# Altium schematic sheet Sheet2.SchDoc(Sheet2)
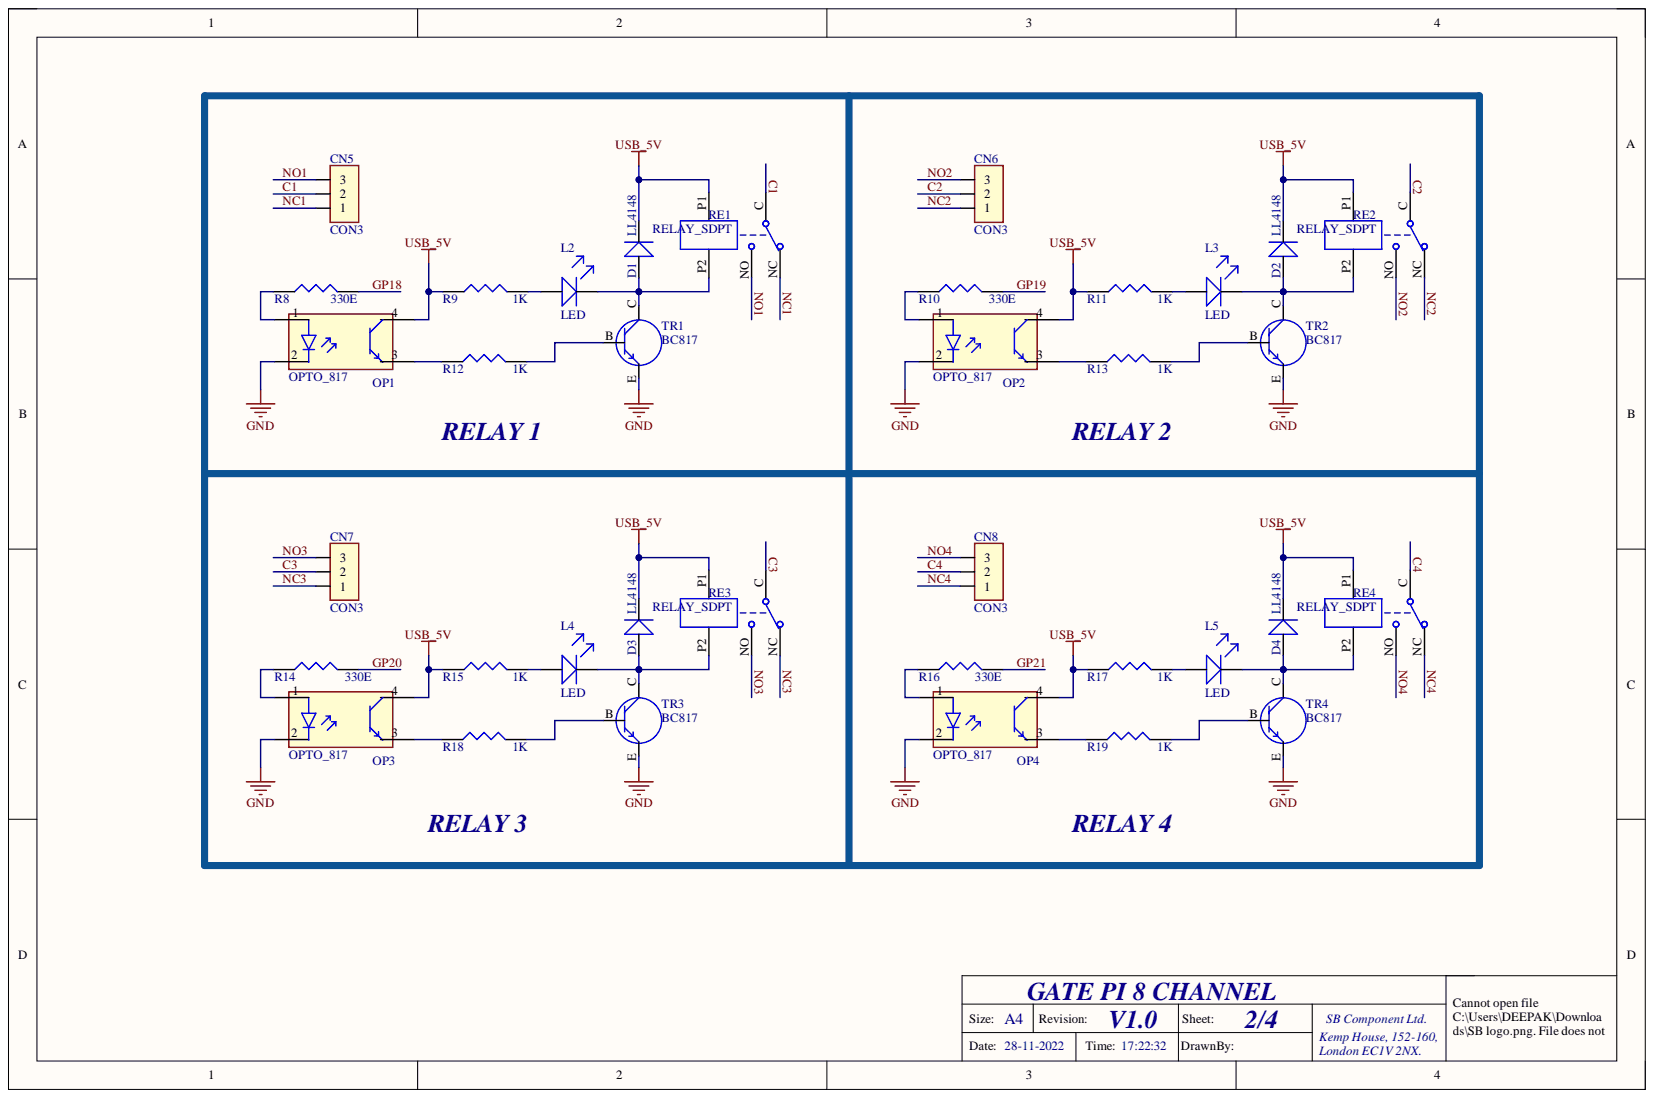
[diagram p0: Sheet2.SchDoc]

## Parts
CN5  CON3  [CON3_5.0_R2]
CN6  CON3  [CON3_5.0_R2]
CN7  CON3  [CON3_5.0_R2]
CN8  CON3  [CON3_5.0_R2]
D1  LL4148  [MINI_MELF_SOD80]
D2  LL4148  [MINI_MELF_SOD80]
D3  LL4148  [MINI_MELF_SOD80]
D4  LL4148  [MINI_MELF_SOD80]
L2  LED  [LED_0603_neg]
L3  LED  [LED_0603_neg]
L4  LED  [LED_0603_neg]
L5  LED  [LED_0603_neg]
OP1  OPTO_817  [OPTO_817_SMD]
OP2  OPTO_817  [OPTO_817_SMD]
OP3  OPTO_817  [OPTO_817_SMD]
OP4  OPTO_817  [OPTO_817_SMD]
R8  330E  [0603]
R9  1K  [0603]
R10  330E  [0603]
R11  1K  [0603]
R12  1K  [0603]
R13  1K  [0603]
R14  330E  [0603]
R15  1K  [0603]
R16  330E  [0603]
R17  1K  [0603]
R18  1K  [0603]
R19  1K  [0603]
RE1  RELAY_SDPT  [RELAY_SDPT]
RE2  RELAY_SDPT  [RELAY_SDPT]
RE3  RELAY_SDPT  [RELAY_SDPT]
RE4  RELAY_SDPT  [RELAY_SDPT]
TR1  BC817  [547_SOT23]
TR2  BC817  [547_SOT23]
TR3  BC817  [547_SOT23]
TR4  BC817  [547_SOT23]

## Nets
GND: OP1.2 OP2.2 OP3.2 OP4.2 TR1.E TR2.E TR3.E TR4.E
USB_5V: D1.K D2.K D3.K D4.K OP1.4 OP2.4 OP3.4 OP4.4 R9.1 R11.1 R15.1 R17.1 RE1.P1 RE2.P1 RE3.P1 RE4.P1
C1: CN5.2 RE1.C
C2: CN6.2 RE2.C
C3: CN7.2 RE3.C
C4: CN8.2 RE4.C
GP18: R8.2
GP19: R10.2
GP20: R14.2
GP21: R16.2
NC1: CN5.1 RE1.NC
NC2: CN6.1 RE2.NC
NC3: CN7.1 RE3.NC
NC4: CN8.1 RE4.NC
NO1: CN5.3 RE1.NO
NO2: CN6.3 RE2.NO
NO3: CN7.3 RE3.NO
NO4: CN8.3 RE4.NO
NetD1_A: D1.A L2.K RE1.P2 TR1.C
NetD2_A: D2.A L3.K RE2.P2 TR2.C
NetD3_A: D3.A L4.K RE3.P2 TR3.C
NetD4_A: D4.A L5.K RE4.P2 TR4.C
NetL2_A: L2.A R9.2
NetL3_A: L3.A R11.2
NetL4_A: L4.A R15.2
NetL5_A: L5.A R17.2
NetOP1_1: OP1.1 R8.1
NetOP1_3: OP1.3 R12.1
NetOP2_1: OP2.1 R10.1
NetOP2_3: OP2.3 R13.1
NetOP3_1: OP3.1 R14.1
NetOP3_3: OP3.3 R18.1
NetOP4_1: OP4.1 R16.1
NetOP4_3: OP4.3 R19.1
NetR12_2: R12.2 TR1.B
NetR13_2: R13.2 TR2.B
NetR18_2: R18.2 TR3.B
NetR19_2: R19.2 TR4.B

## Library data of the parts
D1-D4 = DIODE: Component Kind: Standard; Library Name: dxp_pasl_lib.IntLib
R8-R19 = RES: Component Kind: Standard; Library Name: dxp_pasl_lib.IntLib
TR1-TR4 = TRANSISTER_NPN: Component Kind: Standard; Library Name: dxp_pasl_lib.IntLib
CN5-CN8 = CON3: Component Kind: Standard; Library Name: dxp_pasl_lib.IntLib
L2-L5 = LED: Component Kind: Standard; Library Name: dxp_pasl_lib.IntLib
OP1-OP4 = OPTO_817: Component Kind: Standard; Library Name: dxp_pasl_lib.IntLib
RE1-RE4 = RELAY_SDPT: Component Kind: Standard; Library Name: dxp_pasl_lib.IntLib
